# Altium schematic sheet [1] Alimentation.SchDoc("[1] Alimentation")
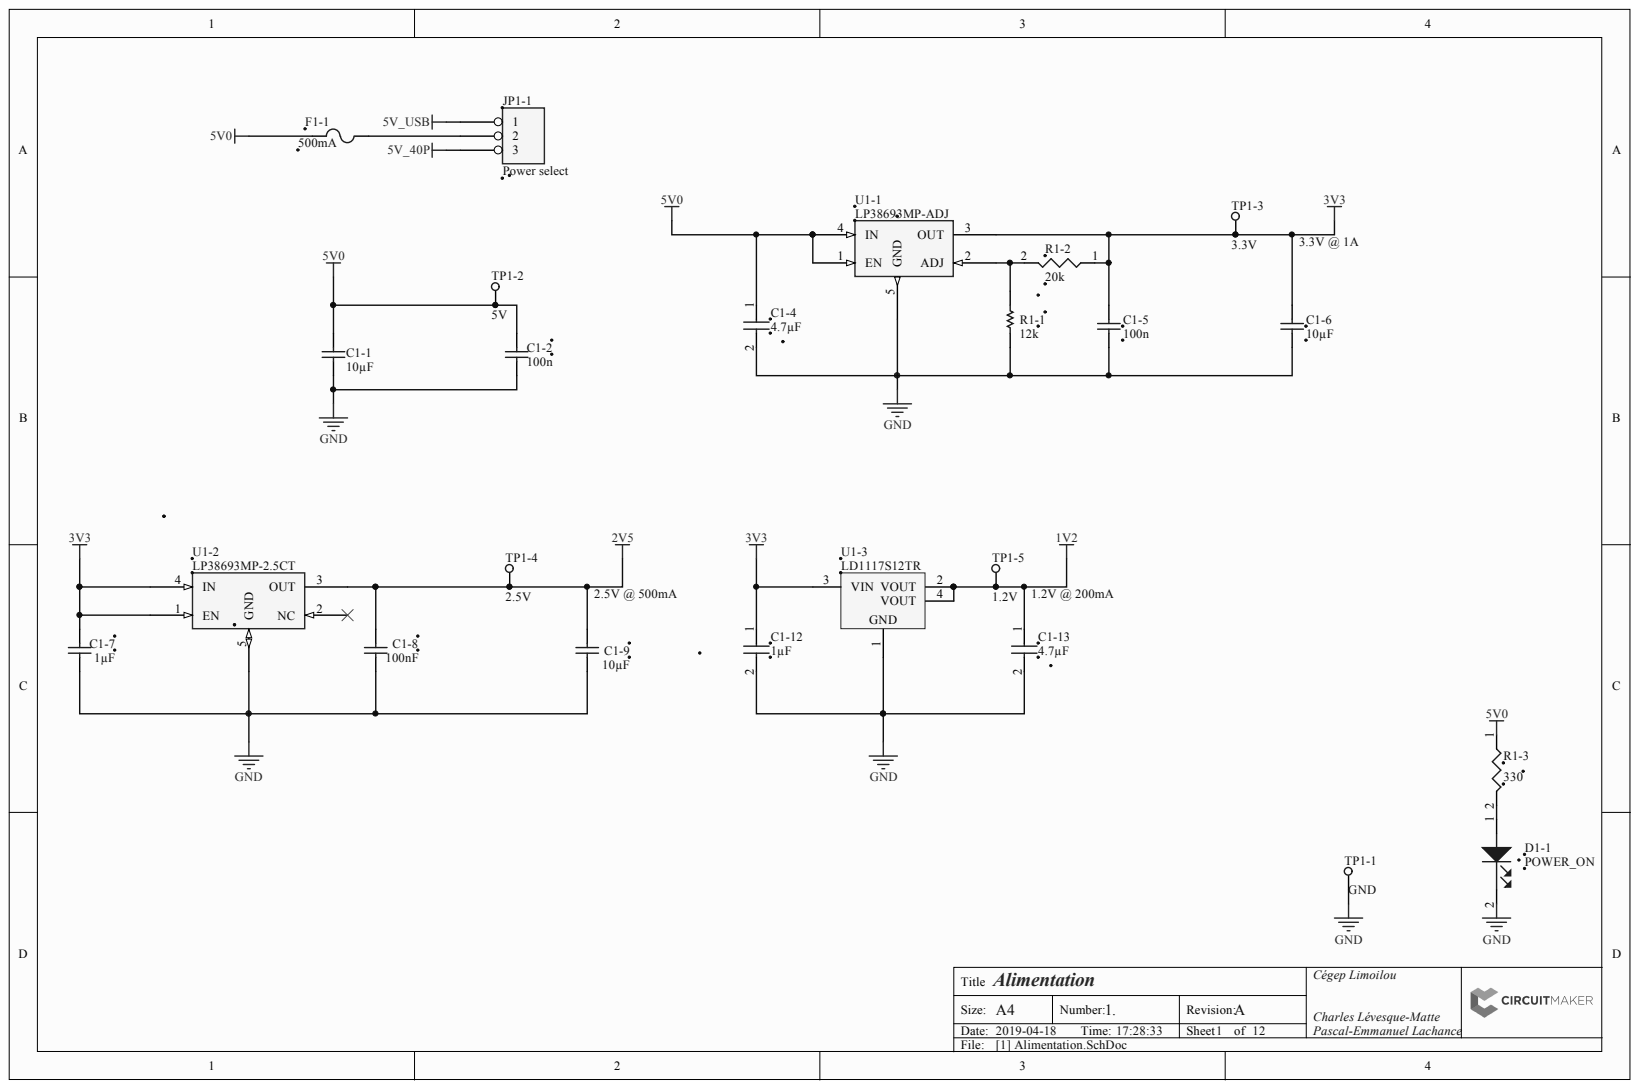
[diagram p0: [1] Alimentation.SchDoc]

## Parts
C1-1  10µF  [Capacitor]
C1-2  100n  [CAPC1608X90X35ML15T15]
C1-4  4.7µF  [PCB-p58dl6gwznckri52t2pm-1]
C1-5  100n  [CAPC1608X90X35ML15T15]
C1-6  10µF  [Capacitor]
C1-7  1µF  [CAPC1608X90X35ML15T15]
C1-8  100nF  [CAPC1608X90X35ML15T15]
C1-9  10µF  [Capacitor]
C1-12  1µF  [PCB-nxtc6s20m69g6ouq0bso-1]
C1-13  4.7µF  [PCB-p58dl6gwznckri52t2pm-1]
D1-1  POWER_ON  [PCB-loj9e2phlcze9wuynh4o-1]
F1-1  500mA  [PCB-yl6a21nh05snp117eqwv-1]
JP1-1  Power select  [PCB-ugwstohemlnv9dppwl48-1]
R1-1  12k  [PCB-d4lxehfvzokpw4haqq2o-1]
R1-2  20k  [PCB-jr3gnteqc9p6l8jw436q-1]
R1-3  330  [PCB-26vbrbvz2r2a5l42s9dt-1]
TP1-1  GND  [PCB-a7rpxwhzqdkz91v608la-1]
TP1-2  5V  [PCB-a7rpxwhzqdkz91v608la-1]
TP1-3  3.3V  [PCB-a7rpxwhzqdkz91v608la-1]
TP1-4  2.5V  [PCB-a7rpxwhzqdkz91v608la-1]
TP1-5  1.2V  [PCB-a7rpxwhzqdkz91v608la-1]
U1-1  LP38693MP-ADJ  [MP05A_N]
U1-2  LP38693MP-2.5CT  [MP05A_M]
U1-3  LD1117S12TR  [SOT-223_N]

## Nets
1V2: C1-13.1 TP1-5.1 U1-3.2(VOUT) U1-3.4(VOUT)
2V5: C1-8.2 C1-9.2 TP1-4.1 U1-2.3(OUT)
3V3: C1-5.2 C1-6.2 C1-7.2 C1-12.1 R1-2.1 TP1-3.1 U1-1.3(OUT) U1-2.1(EN) U1-2.4(IN) U1-3.3(VIN)
5V0: C1-1.2 C1-2.1 C1-4.1 F1-1.2 R1-3.1 TP1-2.1 U1-1.1(EN) U1-1.4(IN)
5V_40P: JP1-1.3
5V_USB: JP1-1.1
GND: C1-1.1 C1-2.2 C1-4.2 C1-5.1 C1-6.1 C1-7.1 C1-8.1 C1-9.1 C1-12.2 C1-13.2 D1-1.2 R1-1.1 TP1-1.1 U1-1.5(GND) U1-2.5(GND) U1-3.1
NetD1-1_1: D1-1.1 R1-3.2
NetF1-1_1: F1-1.1 JP1-1.2
NetR1-1_2: R1-1.2 R1-2.2 U1-1.2(ADJ)

Not connected: U1-2 2(NC)

## Library data of the parts
C1-1 C1-6 C1-9 = CMP-f4d639e26ea32b11-3: Cap Ceramic 10uF 35V X5R 20% Pad SMD 0603 85C T/R; Component Kind: Standard; Library Name: CommunityVault
C1-2 C1-5 C1-7 = CMP-1af8d38ac64bc53f-2: CL10 Series 0.1 uF 50 V ±10% Tolerance X7R SMT Multilayer Ceramic Capacitor; Capacitance: 100 nF; Capacitance Tolerance: ±10%; Component Kind: Standard; Library Name: CommunityVault; Mounting Style: Surface Mount; OctopartID: 1af8d38ac64bc53f; Octopart URL: http://octopart.com/cl10b104kb8nnnc-samsung-7928277; RoHS: Compliant
C1-4 C1-13 = CMP-8860632-7: Manufacturer Part Number: GRM188R6YA475KE15D; Capacitance: 4.70 µF; Capacitance Tolerance: ±10%; Case/Package (SI): 1608; Catalog Drawings: GRM Series_0603_0.8; Dielectric Characteristic: X5R; Dynamic Catalog: GRM Series; Featured Product: GA3 Safety Recognized Caps and Hi-Cap MLCC; Lead-Free Status: Lead Free; Other Names: 490-7205-2; Product Training Modules: High-Cap Multilayer Ceramic Capacitors; Unit PriceUSD: GRM; Voltage - Rated: 35V; Voltage Rating (DC): 35.0 V; Applications: General Purpose; Case/Package: 0603, 1608; Category: Capacitors; CiivaID: 8860632; Ciiva URL: https://ciiva.com/part/grm188r6ya475ke15d-8860632; Component Kind: Standard; Family: Ceramic Capacitors; Library Name: CommunityVault; Lifecycle Status: Active; Minimum Quantity: 4.7µF; Mounting Style: Surface Mount; Mounting Type: Surface Mount, MLCC; OctopartID: 84f04c61162f5b37; Octopart URL: https://octopart.com/grm188r6ya475ke15d-murata-26982329; Online Catalog: GRM Series; Operating Temperature: -55.0 °C (min); Package / Case: 0603 (1608 Metric); Packaging: Tape & Reel (TR), Cut Tape (CT); Quantity Available: Tape & Reel (TR)Alternate Packaging; REACH SVHC Compliance: No SVHC; RoHS: Compliant; Series: GRM; Size-Length: 1.60 mm; Size-Thickness: 800 µm; Size-Width: 800 µm; Size / Dimension: 0.063" L x 0.031" W (1.60mm x 0.80mm); Standard Package: 4,000; Temperature Coefficient: X5R; Thickness (Max): 0.031" (0.80mm); Tolerance: ±10%
C1-8 = CMP-1af8d38ac64bc53f-2: CL10 Series 0.1 uF 50 V ±10% Tolerance X7R SMT Multilayer Ceramic Capacitor; Capacitance: 100 nF; Capacitance Tolerance: ±10%; Component Kind: Standard; Library Name: CommunityVault; Mounting Style: Surface Mount; OctopartID: 1af8d38ac64bc53f; Octopart URL: http://octopart.com/cl10b104kb8nnnc-samsung-7928277; RoHS: Compliant
C1-12 = CMP-f7023f64287128c5-1: Manufacturer Part Number: CL10A105KB8NNNC; Capacitance: 1.00 µF; Capacitance Tolerance: ±10%; Dielectric Characteristic: X5R; Lead-Free Status: Lead Free; Voltage Rating (DC): 50.0 V; Case/Package: 0603; Component Kind: Standard; Library Name: CommunityVault; Mounting Style: Surface Mount; OctopartID: f7023f64287128c5; Octopart URL: http://octopart.com/cl10a105kb8nnnc-samsung-23919125; RoHS: Compliant
D1-1 = CMP-2ca2e5a06a69f365-1: Manufacturer Part Number: LTST-C150CKT; Case/Package (SI): 3216; Color: Red; Forward Voltage: 1.80 V; Lead-Free Status: Lead Free; Lens Type: Non-Diffused; Luminous Intensity: 25.0 mcd; Viewing Angle: 130 °; Wavelength: 660 nm; Case/Package: 1206; Component Kind: Standard; Library Name: CommunityVault; Mounting Style: Surface Mount, Vertical; OctopartID: 2ca2e5a06a69f365; Octopart URL: http://octopart.com/ltst-c150ckt-lite-on-549805; Packaging: Tape & Reel (TR); RoHS: Compliant; Size-Height: 1.10 mm; Size-Length: 3.20 mm; Size-Width: 1.60 mm
F1-1 = CMP-d51f18799e1a0ad8-7: Fuse, Resettable, 100A Max, 0.5A Hold, 24VDC, SMD/SMT, 1 Ohms, miniSMDC Series; Manufacturer Part Number: MINISMDC050F-2; ELV Compliant: Compliant; Lead-Free Status: Lead Free; Power Dissipation: 800 mW; Resistance: 150 mΩ; Time to Trip: 150 ms; Tripping Current: 1.00 A; Component Kind: Standard; Holding Current: 500 mA; Library Name: CommunityVault; Mounting Style: Surface Mount; OctopartID: d51f18799e1a0ad8; Packaging: Tape & Reel (TR); REACH SVHC Compliance: No SVHC; Size-Height: .50 mm; Size-Length: 4.73 mm; Size-Width: 3.41 mm; Test: Test
JP1-1 = CMP-e6852ab6724f3942-2: Manufacturer Part Number: PR20203VBNN; Gender: Male; Component Kind: Standard; Contact Plating: Gold; Library Name: CommunityVault; Mounting Style: Solder; OctopartID: e6852ab6724f3942; Octopart URL: https://octopart.com/pr20203vbnn-metz+connect-87667116; RoHS: Compliant
R1-1 = CMP-c7f00fdfc8011837-3: Res Thick Film 0603 12K Ohm 1% 0.1W(1/10W) ±100ppm/C Pad SMD Automotive T/R; Lead-Free Status: Lead Free; Power Rating: 100 mW; Component Kind: Standard; Library Name: CommunityVault; OctopartID: c7f00fdfc8011837
R1-2 = CMP-0edac6f500b3d11d-1: Manufacturer Part Number: RMCF0603FT20K0; Lead-Free Status: Lead Free; Power Rating: 100 mW; Resistance: 20.0 kΩ; Resistance Tolerance: ±1%; Case/Package: 0603; Component Kind: Standard; Library Name: CommunityVault; Mounting Style: Surface Mount; OctopartID: 0edac6f500b3d11d; Octopart URL: https://octopart.com/rmcf0603ft20k0-stackpole+electronics-19213071; Packaging: Tape & Reel (TR); RoHS: Compliant
R1-3 = CMP-519899b75f918e5f-1: Manufacturer Part Number: RMCF0603FT330R; Lead-Free Status: Lead Free; Power Rating: 100 mW; Resistance: 330 Ω; Resistance Tolerance: ±1%; Case/Package: 0603; Component Kind: Standard; Library Name: CommunityVault; Mounting Style: Surface Mount; OctopartID: 519899b75f918e5f; Octopart URL: http://octopart.com/rmcf0603ft330r-stackpole+electronics-19213167; Packaging: Tape & Reel (TR); RoHS: Compliant
TP1-1-TP1-5 = CMP-5j384tb079h9dvgbp04a-2: Through-hole Testpoint; Component Kind: Standard; Library Name: CommunityVault
U1-1 = CMP-0062-01809-4: Manufacturer: TI (Texas Instruments) / National Semiconductor; Manufacturer Part Number: LP38693MP-ADJ/NOPB; Accuracy %: 2%; Base Number: 38693; CiivaID: 1495913; Dropout Voltage: 0.25 V; Dropout Voltage Vdo: 250mV; Input Voltage Max: 10V; Lead-Free Status: Lead Free; No. of Outputs: 1; No. of Pins: 5; Output Capacitor Type: Ceramic; Output Current: 0.5 A; Output Current Max: 500mA; Output Voltage: 9V; Output Voltage Adjustable Range: 1.25V to 9V; Quiescent Current: 5.5e-05 A; Supply Voltage Min: 2.7V; SVHC: No SVHC (20-Jun-2013); Voltage Regulator Case Style: SOT-223; Voltage Regulator Type: LDO Adjustable Positive; Case/Package: SOT-223; Ciiva URL: https://ciiva.com/part/lp38693mp-adj-nopb-1495913; Component Kind: Standard; Library Name: CommunityVault; Lifecycle Status: Active; Mounting Style: Surface Mount; MSL: MSL 1 - Unlimited; Number of Outputs: 1; Number of Pins: 5; Number of Regulated Outputs: 1; OctopartID: 3501fd71bbc9eac6; Octopart Url: https://octopart.com/lp38693mp-adj%2Fnopb-texas+instruments-24816558; Operating Temperature Max: 125Â°C; Operating Temperature Min: -40Â°C; Operating Temperature Range: -40Â°C to +125Â°C; Output Voltage Max: 9V; Package / Case: SOT-223; Primary Input Voltage: 10V; REACH SVHC Compliance: No SVHC; RoHS: Compliant; Supply Voltage Max: 10V; Termination Type: SMD
U1-2 = CMP-1497039-4: Manufacturer: Texas Instruments; Manufacturer Part Number: LP38693MP-2.5/NOPB; Accuracy: Â±2 %; Dropout Voltage: 0.43 V; Lead-Free Status: Lead Free; Line Regulation: 0.03(Typ) %/V; Load Regulation: 1.8(Typ) %/A; Maximum Input Voltage: 10 V; Maximum Output Current: 0.5 A; Maximum Quiescent Current: 0.1 mA; Minimum Input Voltage: 2.7 V; Number of Outputs: 1; Output Capacitor Type: Ceramic; Output Type: Fixed; Output Voltage: 2.5 V; Polarity: Positive; Quiescent Current: 5.5e-05 A; Rad Hard: No; Typical Dropout Voltage @ Current: 0.08@100mA|0.43@500mA V; Category: Linear Regulator; CiivaID: 1497039; Ciiva URL: https://ciiva.com/part/lp38693mp-2-5-nopb-1497039; Component Kind: Standard; Library Name: CommunityVault; Lifecycle Status: Active; Mounting: Surface Mount; Number of Pins: 5; Number of Regulated Outputs: 1; OctopartID: 87554f2f32805892; Octopart Url: https://octopart.com/lp38693mp-2.5%2Fnopb-texas+instruments-24154291; Operating Temperature: -40 to 125 Â°C; Output Current: 0.5 A; Package: 5SOT-223; Packaging: Tape & Reel; REACH SVHC Compliance: No SVHC; RoHS: Compliant; Type: Linear
U1-3 = CMP-13266185-2: Fixed Low Drop Positive Voltage Regulator, 1.2V, 4-Pin SOT-223, Tape and Reel; Dropout Voltage: 1.1 V; Lead-Free Status: Lead Free; Number of Outputs: 1; Output Current: 0.8 A; Case/Package: SOT-223; Component Kind: Standard; Library Name: CommunityVault; Lifecycle Status: Active; Manufacturer Part Number: LD1117S12TR; Mounting Style: Surface Mount; Number of Pins: 3; OctopartID: cefd07ffe14e113a; Octopart Url: https://octopart.com/ld1117s12tr-stmicroelectronics-527061; REACH SVHC Compliance: No SVHC; RoHS: Compliant
